# Altium schematic sheet Sheet1.SchDoc(Sheet1)
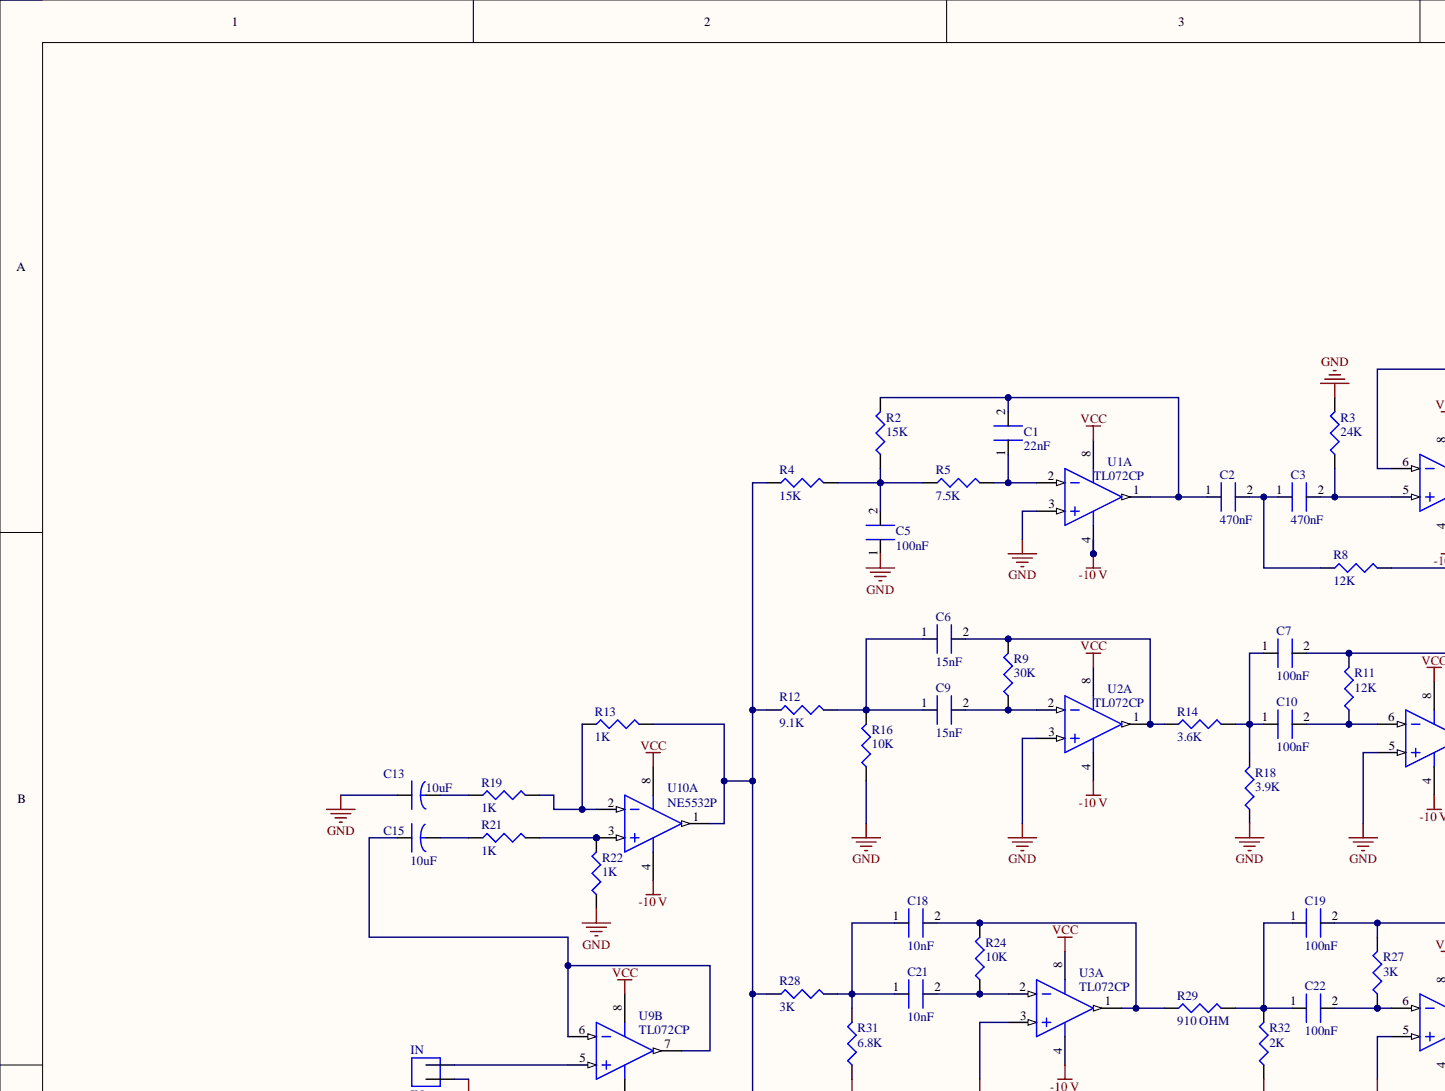
[diagram p0: Sheet1.SchDoc, top left]
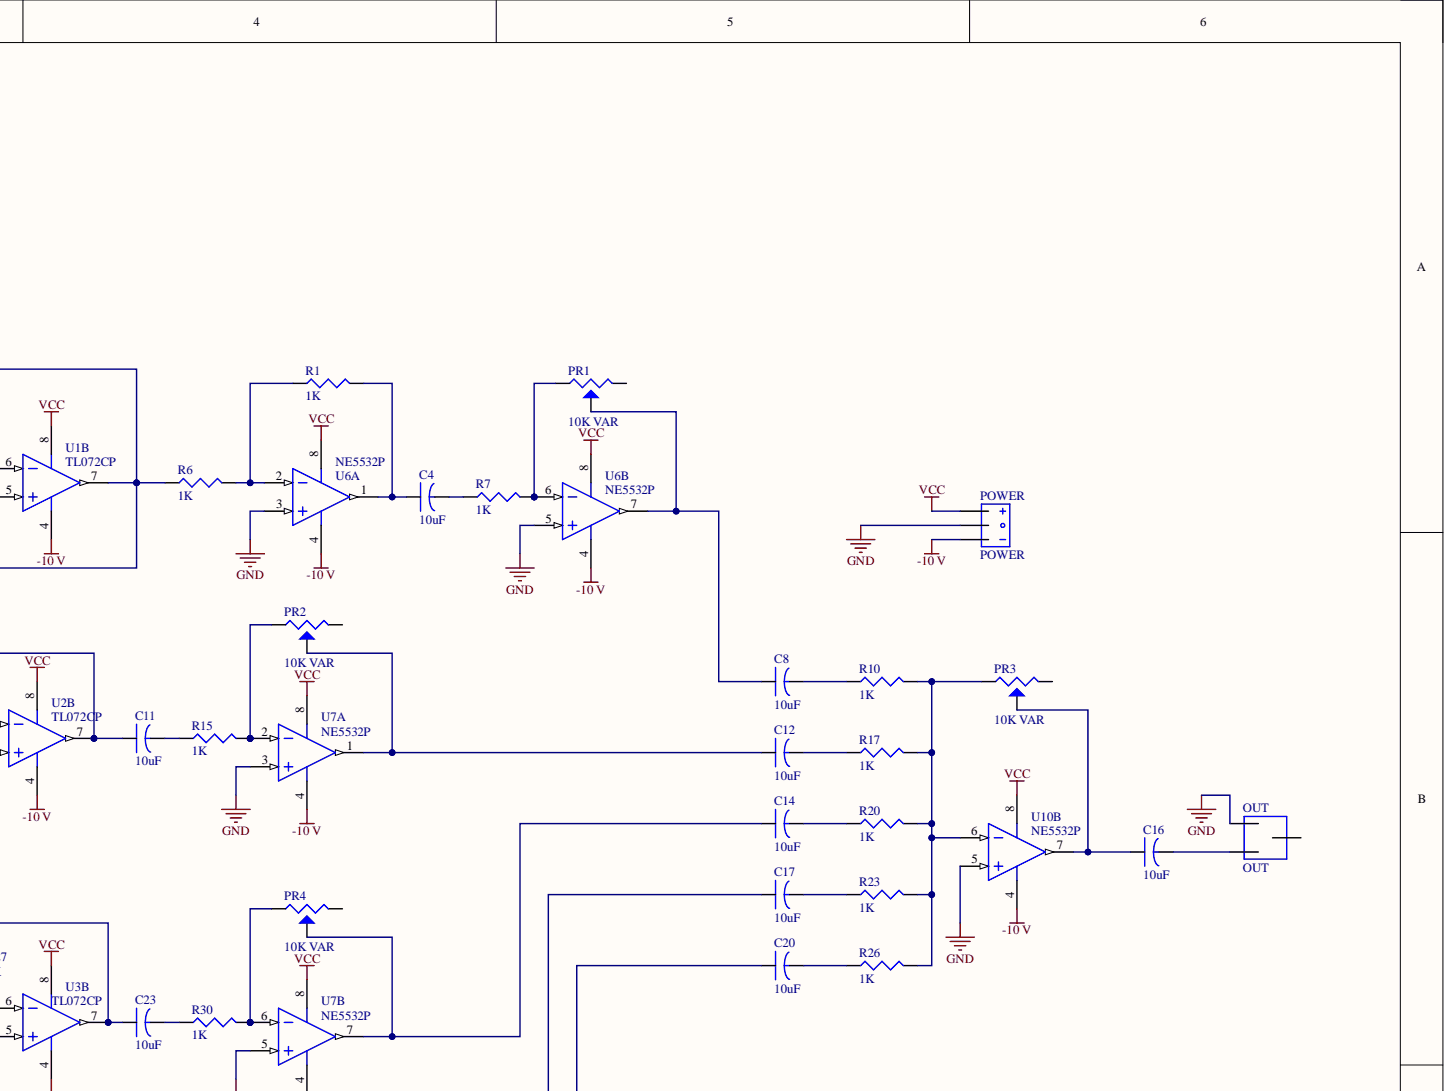
[diagram p1: Sheet1.SchDoc, top right]
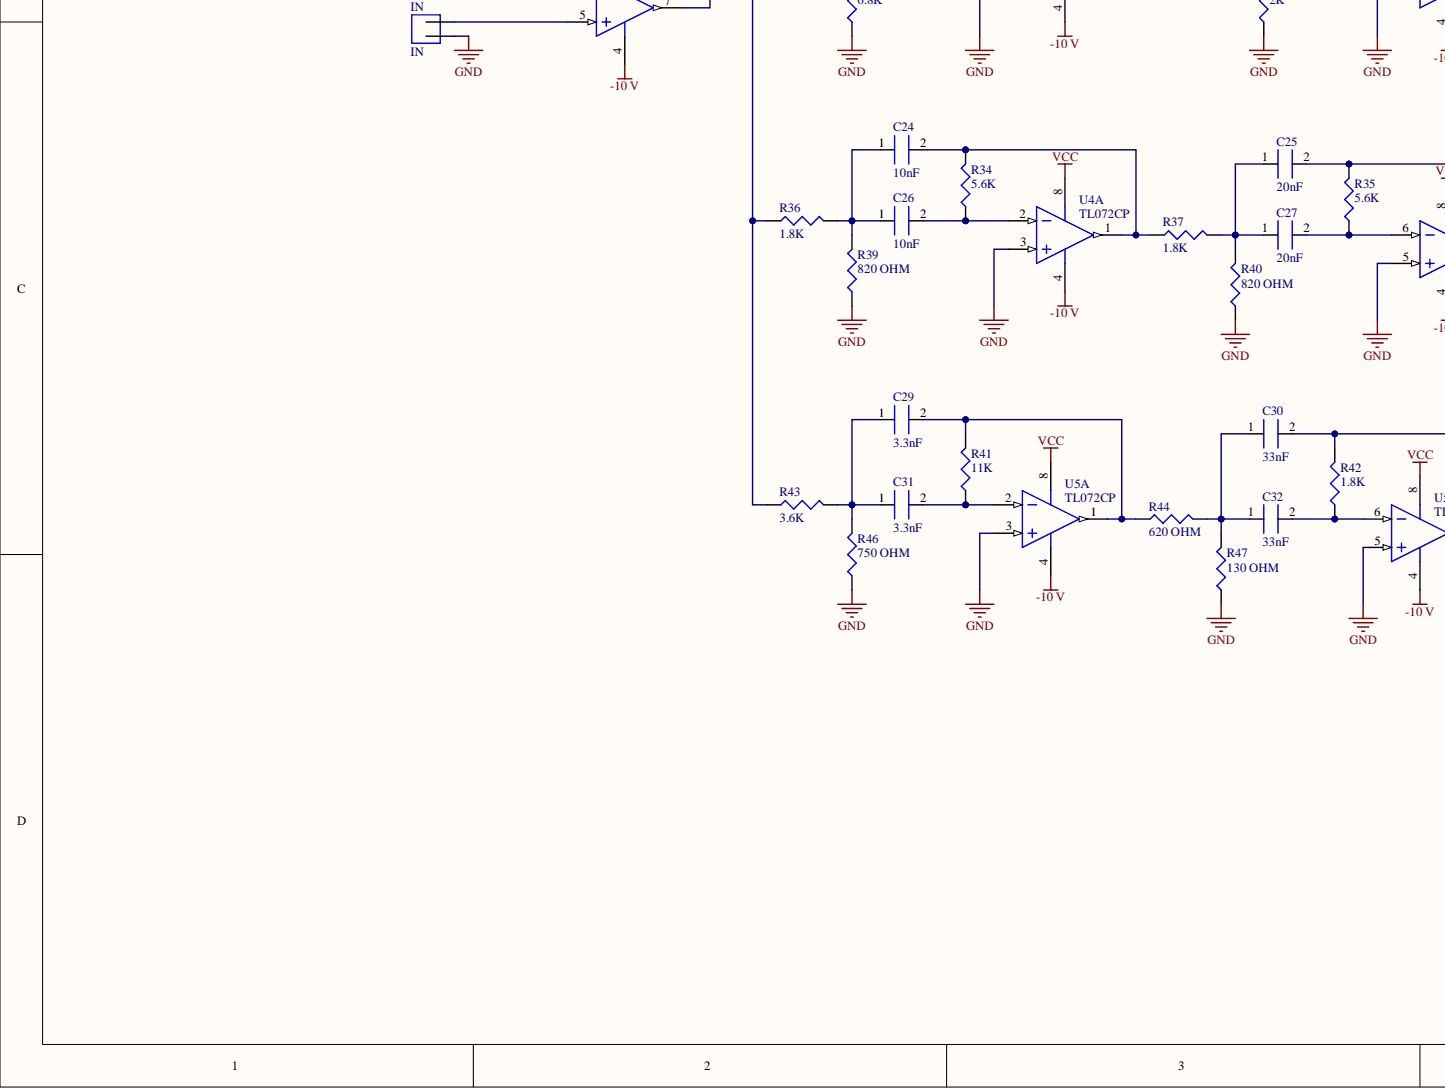
[diagram p2: Sheet1.SchDoc, bottom left]
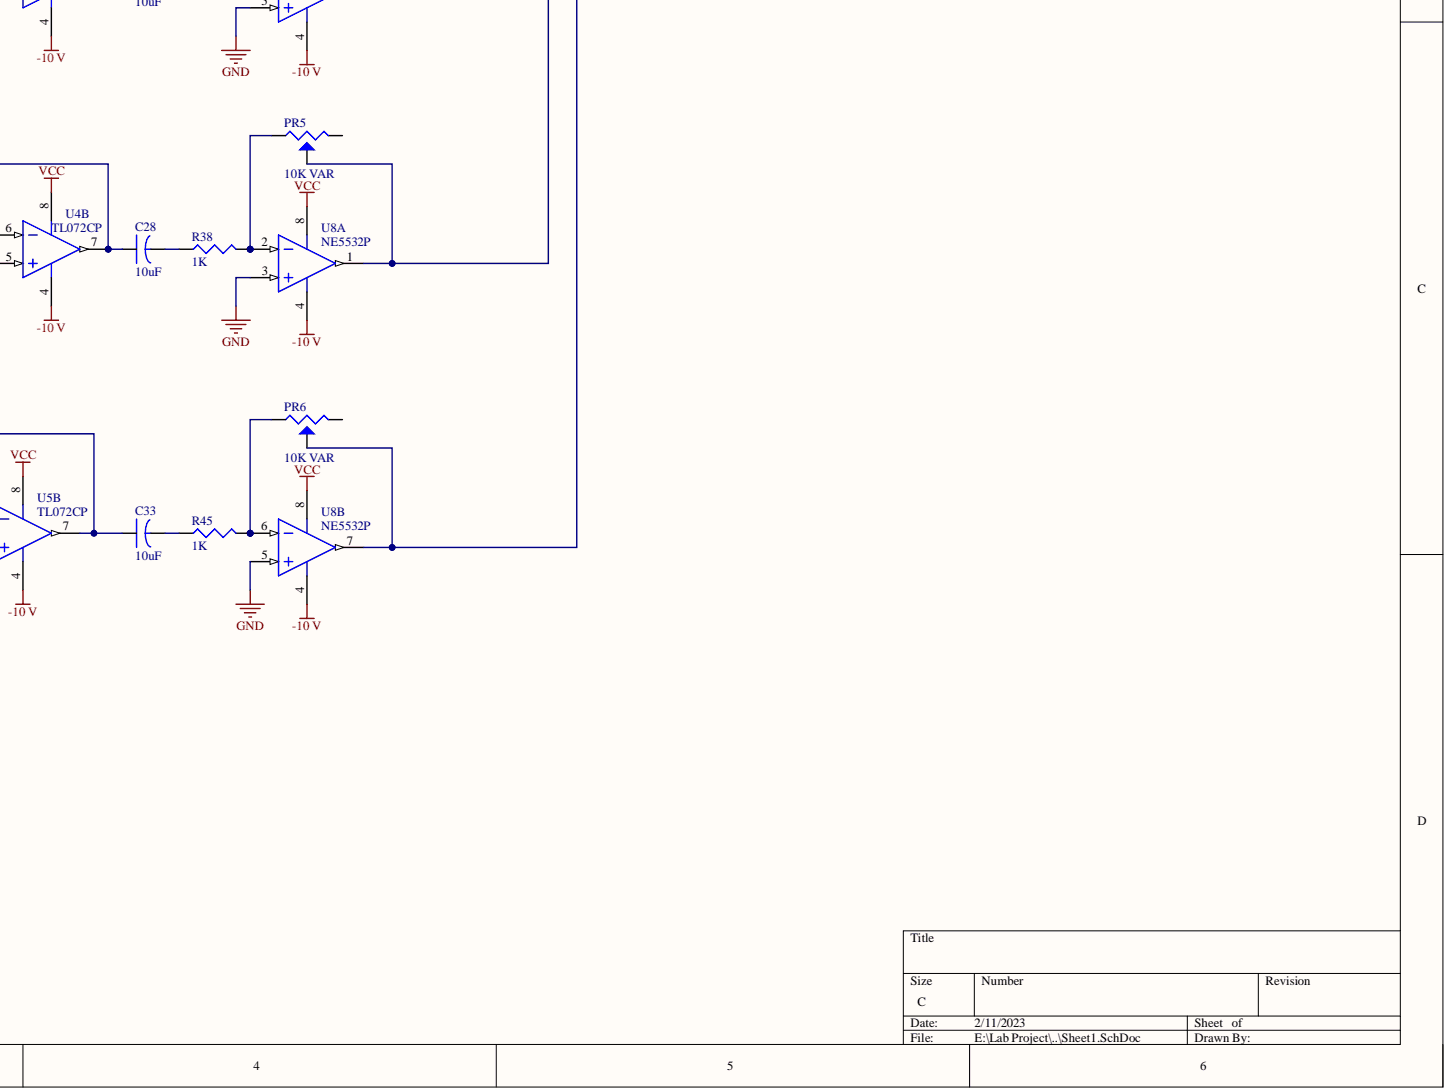
[diagram p3: Sheet1.SchDoc, bottom right]

## Parts
C1  22nF  [10nF]
C2  470nF  [100nf]
C3  470nF  [100nf]
C4  10uF  [10uF]
C5  100nF  [100nf]
C6  15nF  [10nF]
C7  100nF  [100nf]
C8  10uF  [10uF]
C9  15nF  [10nF]
C10  100nF  [100nf]
C11  10uF  [10uF]
C12  10uF  [10uF]
C13  10uF  [10uF]
C14  10uF  [10uF]
C15  10uF  [10uF]
C16  10uF  [10uF]
C17  10uF  [10uF]
C18  10nF  [10nF]
C19  100nF  [100nf]
C20  10uF  [10uF]
C21  10nF  [10nF]
C22  100nF  [100nf]
C23  10uF  [10uF]
C24  10nF  [10nF]
C25  20nF  [10nF]
C26  10nF  [10nF]
C27  20nF  [10nF]
C28  10uF  [10uF]
C29  3.3nF  [10nF]
C30  33nF  [10nF]
C31  3.3nF  [10nF]
C32  33nF  [10nF]
C33  10uF  [10uF]
IN  IN  [AUDIO_IN]
OUT  OUT  [AUDIO_OUT]
POWER  POWER  [POWER]
PR1  10K VAR  [POT]
PR2  10K VAR  [POT]
PR3  10K VAR  [POT]
PR4  10K VAR  [POT]
PR5  10K VAR  [POT]
PR6  10K VAR  [POT]
R1  1K  [RESI]
R2  15K  [RESI]
R3  24K  [RESI]
R4  15K  [RESI]
R5  7.5K  [RESI]
R6  1K  [RESI]
R7  1K  [RESI]
R8  12K  [RESI]
R9  30K  [RESI]
R10  1K  [RESI]
R11  12K  [RESI]
R12  9.1K  [RESI]
R13  1K  [RESI]
R14  3.6K  [RESI]
R15  1K  [RESI]
R16  10K  [RESI]
R17  1K  [RESI]
R18  3.9K  [RESI]
R19  1K  [RESI]
R20  1K  [RESI]
R21  1K  [RESI]
R22  1K  [RESI]
R23  1K  [RESI]
R24  10K  [RESI]
R26  1K  [RESI]
R27  3K  [RESI]
R28  3K  [RESI]
R29  910 OHM  [RESI]
R30  1K  [RESI]
R31  6.8K  [RESI]
R32  2K  [RESI]
R34  5.6K  [RESI]
R35  5.6K  [RESI]
R36  1.8K  [RESI]
R37  1.8K  [RESI]
R38  1K  [RESI]
R39  820 OHM  [RESI]
R40  820 OHM  [RESI]
R41  11K  [RESI]
R42  1.8K  [RESI]
R43  3.6K  [RESI]
R44  620 OHM  [RESI]
R45  1K  [RESI]
R46  750 OHM  [RESI]
R47  130 OHM  [RESI]
U1  TL072CP  [TL0072CP]  (parts A,B)
U2  TL072CP  [TL0072CP]  (parts A,B)
U3  TL072CP  [TL0072CP]  (parts A,B)
U4  TL072CP  [TL0072CP]  (parts A,B)
U5  TL072CP  [TL0072CP]  (parts A,B)
U6  NE5532P  [NE5532P]  (parts A,B)
U7  NE5532P  [NE5532P]  (parts A,B)
U8  NE5532P  [NE5532P]  (parts A,B)
U9  TL072CP  [TL0072CP]  (part B)
U10  NE5532P  [NE5532P]  (parts A,B)

## Nets
-10 V: POWER.3 U1.4 U2.4 U3.4 U4.4 U5.4 U6.4 U7.4 U8.4 U9.4 U10.4
GND: C5.1 C13.1 IN.1 OUT.2 POWER.2 R3.2 R16.1 R18.1 R22.1 R31.1 R32.1 R39.1 R40.1 R46.1 R47.1 U1.3 U2.3 U2.5 U3.3 U3.5 U4.3 U4.5 U5.3 U5.5 U6.3 U6.5 U7.3 U7.5 U8.3 U8.5 U10.5
VCC: POWER.1 U1.8 U2.8 U3.8 U4.8 U5.8 U6.8 U7.8 U8.8 U9.8 U10.8
NetC1_1: C1.1 R5.2 U1.2
NetC1_2: C1.2 C2.1 R2.2 U1.1
NetC2_2: C2.2 C3.1 R8.1
NetC3_2: C3.2 R3.1 U1.5
NetC4_1: C4.1 R1.2 U6.1
NetC4_2: C4.2 R7.1
NetC5_2: C5.2 R2.1 R4.2 R5.1
NetC6_1: C6.1 C9.1 R12.2 R16.2
NetC6_2: C6.2 R9.2 R14.1 U2.1
NetC7_1: C7.1 C10.1 R14.2 R18.2
NetC7_2: C7.2 C11.1 R11.2 U2.7
NetC8_1: C8.1 PR1.2 U6.7
NetC8_2: C8.2 R10.1
NetC9_2: C9.2 R9.1 U2.2
NetC10_2: C10.2 R11.1 U2.6
NetC11_2: C11.2 R15.1
NetC12_1: C12.1 PR2.2 U7.1
NetC12_2: C12.2 R17.1
NetC13_2: C13.2 R19.1
NetC14_1: C14.1 PR4.2 U7.7
NetC14_2: C14.2 R20.1
NetC15_1: C15.1 U9.6 U9.7
NetC15_2: C15.2 R21.1
NetC16_1: C16.1 PR3.2 U10.7
NetC16_2: C16.2 OUT.3
NetC17_1: C17.1 PR5.2 U8.1
NetC17_2: C17.2 R23.1
NetC18_1: C18.1 C21.1 R28.2 R31.2
NetC18_2: C18.2 R24.2 R29.1 U3.1
NetC19_1: C19.1 C22.1 R29.2 R32.2
NetC19_2: C19.2 C23.1 R27.2 U3.7
NetC20_1: C20.1 PR6.2 U8.7
NetC20_2: C20.2 R26.1
NetC21_2: C21.2 R24.1 U3.2
NetC22_2: C22.2 R27.1 U3.6
NetC23_2: C23.2 R30.1
NetC24_1: C24.1 C26.1 R36.2 R39.2
NetC24_2: C24.2 R34.2 R37.1 U4.1
NetC25_1: C25.1 C27.1 R37.2 R40.2
NetC25_2: C25.2 C28.1 R35.2 U4.7
NetC26_2: C26.2 R34.1 U4.2
NetC27_2: C27.2 R35.1 U4.6
NetC28_2: C28.2 R38.1
NetC29_1: C29.1 C31.1 R43.2 R46.2
NetC29_2: C29.2 R41.2 R44.1 U5.1
NetC30_1: C30.1 C32.1 R44.2 R47.2
NetC30_2: C30.2 C33.1 R42.2 U5.7
NetC31_2: C31.2 R41.1 U5.2
NetC32_2: C32.2 R42.1 U5.6
NetC33_2: C33.2 R45.1
NetIN_2: IN.2 U9.5
NetPR1_1: PR1.1 R7.2 U6.6
NetPR2_1: PR2.1 R15.2 U7.2
NetPR3_1: PR3.1 R10.2 R17.2 R20.2 R23.2 R26.2 U10.6
NetPR4_1: PR4.1 R30.2 U7.6
NetPR5_1: PR5.1 R38.2 U8.2
NetPR6_1: PR6.1 R45.2 U8.6
NetR1_1: R1.1 R6.2 U6.2
NetR4_1: R4.1 R12.1 R13.2 R28.1 R36.1 R43.1 U10.1
NetR6_1: R6.1 R8.2 U1.6 U1.7
NetR13_1: R13.1 R19.2 U10.2
NetR21_2: R21.2 R22.2 U10.3

Not connected: OUT 1; PR1 3; PR2 3; PR3 3; PR4 3; PR5 3; PR6 3

## Library data of the parts
C1 = 22nF: Manufacturer: Nichicon; Manufacturer Part Number: UKW1H0R1MDD1TD; Capacitance: 100nF; Life (Hours): 2000Hour; Ripple Current: 1.1mA; Tolerance: 20%; Voltage Rating: 50V; Case/Package: Radial; Category: Aluminum Electrolytic Capacitors; Component Kind: Standard; ComponentLink1Description: https://datasheet.ciiva.com/29550/e-kw-29550927.pdf?src-supplier=Digi-Key; ComponentLink1URL: https://datasheet.ciiva.com/29550/e-kw-29550927.pdf?src-supplier=Digi-Key; Height - Seated (Max): 0.492inch; Lead Pitch: 0.079inch; Library Name: Schlib1.SchLib; Max Operating Temperature: 85°C; Min Operating Temperature: -40°C; Mount: Through Hole; Package Quantity: 1; Packaging: Cut Tape; Pricing 1: 1=0.39000, 10=0.26600, 50=0.17720, 100=0.15050, 500=0.10626, 1000=0.09298 (USD); RoHS: Yes; RoHS Compliant: Yes; Stock 1: 3707; Supplier 1: Digi-Key; Supplier Part Number 1: 493-10793-1-ND
C2 C3 = 470nF: Manufacturer: Nichicon; Manufacturer Part Number: UKW1H0R1MDD1TD; Life (Hours): 2000Hour; Ripple Current: 1.1mA; Tolerance: 20%; Voltage Rating: 50V; Capacitance: 100nF; Case/Package: Radial; Category: Aluminum Electrolytic Capacitors; Component Kind: Standard; ComponentLink1Description: https://datasheet.ciiva.com/29550/e-kw-29550927.pdf?src-supplier=Digi-Key; ComponentLink1URL: https://datasheet.ciiva.com/29550/e-kw-29550927.pdf?src-supplier=Digi-Key; Height - Seated (Max): 0.492inch; Lead Pitch: 0.079inch; Library Name: Schlib1.SchLib; Max Operating Temperature: 85°C; Min Operating Temperature: -40°C; Mount: Through Hole; Package Quantity: 1; Packaging: Cut Tape; Pricing 1: 1=0.39000, 10=0.26600, 50=0.17720, 100=0.15050, 500=0.10626, 1000=0.09298 (USD); RoHS: Yes; RoHS Compliant: Yes; Stock 1: 3707; Supplier 1: Digi-Key; Supplier Part Number 1: 493-10793-1-ND
C4 C8 C11-C17 C20 C23 C28 C33 = 10uF: YXF series 50V 10uF 5x11mm LS=2mm 105'C 10k HRS Aluminum Electrolytic Capacitor; Manufacturer: Rubycon; Manufacturer Part Number: 50YXF10MEFC5X11; Dielectric Material: Aluminium; Impedance: 1.5R; Life (Hours): 5000Hour; Ripple Current: 100mA; Tolerance: 20%; Capacitance: 10uF; Case/Package: Radial; Category: Aluminum Electrolytic Capacitors; Component Kind: Standard; ComponentLink1Description: https://datasheet.ciiva.com/7976/e-yxf-7976037.pdf?src-supplier=Element14; ComponentLink1URL: https://datasheet.ciiva.com/7976/e-yxf-7976037.pdf?src-supplier=Element14; ComponentLink2Description: https://datasheet.ciiva.com/6310/e-yxf-6310901.pdf?src-supplier=Digi-Key; ComponentLink2URL: https://datasheet.ciiva.com/6310/e-yxf-6310901.pdf?src-supplier=Digi-Key; Diameter: 5mm; Height: 11mm; Height - Seated (Max): 0.492inch; Lead Pitch: 2mm; Library Name: Schlib1.SchLib; Max Operating Temperature: 105°C; Min Operating Temperature: -40°C; Mount: Through Hole; Package Quantity: 3000; Packaging: Bulk; Pricing 1: 1=0.31000, 10=0.21000, 50=0.12580, 100=0.10620, 500=0.07266, 1000=0.06427, 2500=0.06148, 5000=0.05589 (USD); Radiation Hardening: No; RoHS: Yes; RoHS Compliant: Yes; Series: YXF; Stock 1: 126340; Supplier 1: Digi-Key; Supplier Part Number 1: 1189-1852-ND; Termination: Radial; Voltage Rating: 50V
C5 C7 C10 C19 C22 = 100nF: Manufacturer: Nichicon; Manufacturer Part Number: UKW1H0R1MDD1TD; Life (Hours): 2000Hour; Ripple Current: 1.1mA; Tolerance: 20%; Voltage Rating: 50V; Capacitance: 100nF; Case/Package: Radial; Category: Aluminum Electrolytic Capacitors; Component Kind: Standard; ComponentLink1Description: https://datasheet.ciiva.com/29550/e-kw-29550927.pdf?src-supplier=Digi-Key; ComponentLink1URL: https://datasheet.ciiva.com/29550/e-kw-29550927.pdf?src-supplier=Digi-Key; Height - Seated (Max): 0.492inch; Lead Pitch: 0.079inch; Library Name: Schlib1.SchLib; Max Operating Temperature: 85°C; Min Operating Temperature: -40°C; Mount: Through Hole; Package Quantity: 1; Packaging: Cut Tape; Pricing 1: 1=0.39000, 10=0.26600, 50=0.17720, 100=0.15050, 500=0.10626, 1000=0.09298 (USD); RoHS: Yes; RoHS Compliant: Yes; Stock 1: 3707; Supplier 1: Digi-Key; Supplier Part Number 1: 493-10793-1-ND
C6 C9 = 15nF: Manufacturer: Nichicon; Manufacturer Part Number: UKW1H0R1MDD1TD; Capacitance: 100nF; Life (Hours): 2000Hour; Ripple Current: 1.1mA; Tolerance: 20%; Voltage Rating: 50V; Case/Package: Radial; Category: Aluminum Electrolytic Capacitors; Component Kind: Standard; ComponentLink1Description: https://datasheet.ciiva.com/29550/e-kw-29550927.pdf?src-supplier=Digi-Key; ComponentLink1URL: https://datasheet.ciiva.com/29550/e-kw-29550927.pdf?src-supplier=Digi-Key; Height - Seated (Max): 0.492inch; Lead Pitch: 0.079inch; Library Name: Schlib1.SchLib; Max Operating Temperature: 85°C; Min Operating Temperature: -40°C; Mount: Through Hole; Package Quantity: 1; Packaging: Cut Tape; Pricing 1: 1=0.39000, 10=0.26600, 50=0.17720, 100=0.15050, 500=0.10626, 1000=0.09298 (USD); RoHS: Yes; RoHS Compliant: Yes; Stock 1: 3707; Supplier 1: Digi-Key; Supplier Part Number 1: 493-10793-1-ND
C18 C21 C24 C26 = 10nF: Manufacturer: Nichicon; Manufacturer Part Number: UKW1H0R1MDD1TD; Capacitance: 100nF; Life (Hours): 2000Hour; Ripple Current: 1.1mA; Tolerance: 20%; Voltage Rating: 50V; Case/Package: Radial; Category: Aluminum Electrolytic Capacitors; Component Kind: Standard; ComponentLink1Description: https://datasheet.ciiva.com/29550/e-kw-29550927.pdf?src-supplier=Digi-Key; ComponentLink1URL: https://datasheet.ciiva.com/29550/e-kw-29550927.pdf?src-supplier=Digi-Key; Height - Seated (Max): 0.492inch; Lead Pitch: 0.079inch; Library Name: Schlib1.SchLib; Max Operating Temperature: 85°C; Min Operating Temperature: -40°C; Mount: Through Hole; Package Quantity: 1; Packaging: Cut Tape; Pricing 1: 1=0.39000, 10=0.26600, 50=0.17720, 100=0.15050, 500=0.10626, 1000=0.09298 (USD); RoHS: Yes; RoHS Compliant: Yes; Stock 1: 3707; Supplier 1: Digi-Key; Supplier Part Number 1: 493-10793-1-ND
C25 C27 = 20nF: Manufacturer: Nichicon; Manufacturer Part Number: UKW1H0R1MDD1TD; Capacitance: 100nF; Life (Hours): 2000Hour; Ripple Current: 1.1mA; Tolerance: 20%; Voltage Rating: 50V; Case/Package: Radial; Category: Aluminum Electrolytic Capacitors; Component Kind: Standard; ComponentLink1Description: https://datasheet.ciiva.com/29550/e-kw-29550927.pdf?src-supplier=Digi-Key; ComponentLink1URL: https://datasheet.ciiva.com/29550/e-kw-29550927.pdf?src-supplier=Digi-Key; Height - Seated (Max): 0.492inch; Lead Pitch: 0.079inch; Library Name: Schlib1.SchLib; Max Operating Temperature: 85°C; Min Operating Temperature: -40°C; Mount: Through Hole; Package Quantity: 1; Packaging: Cut Tape; Pricing 1: 1=0.39000, 10=0.26600, 50=0.17720, 100=0.15050, 500=0.10626, 1000=0.09298 (USD); RoHS: Yes; RoHS Compliant: Yes; Stock 1: 3707; Supplier 1: Digi-Key; Supplier Part Number 1: 493-10793-1-ND
C29 C31 = 3.3nF: Manufacturer: Nichicon; Manufacturer Part Number: UKW1H0R1MDD1TD; Capacitance: 100nF; Life (Hours): 2000Hour; Ripple Current: 1.1mA; Tolerance: 20%; Voltage Rating: 50V; Case/Package: Radial; Category: Aluminum Electrolytic Capacitors; Component Kind: Standard; ComponentLink1Description: https://datasheet.ciiva.com/29550/e-kw-29550927.pdf?src-supplier=Digi-Key; ComponentLink1URL: https://datasheet.ciiva.com/29550/e-kw-29550927.pdf?src-supplier=Digi-Key; Height - Seated (Max): 0.492inch; Lead Pitch: 0.079inch; Library Name: Schlib1.SchLib; Max Operating Temperature: 85°C; Min Operating Temperature: -40°C; Mount: Through Hole; Package Quantity: 1; Packaging: Cut Tape; Pricing 1: 1=0.39000, 10=0.26600, 50=0.17720, 100=0.15050, 500=0.10626, 1000=0.09298 (USD); RoHS: Yes; RoHS Compliant: Yes; Stock 1: 3707; Supplier 1: Digi-Key; Supplier Part Number 1: 493-10793-1-ND
C30 C32 = 33nF: Manufacturer: Nichicon; Manufacturer Part Number: UKW1H0R1MDD1TD; Capacitance: 100nF; Life (Hours): 2000Hour; Ripple Current: 1.1mA; Tolerance: 20%; Voltage Rating: 50V; Case/Package: Radial; Category: Aluminum Electrolytic Capacitors; Component Kind: Standard; ComponentLink1Description: https://datasheet.ciiva.com/29550/e-kw-29550927.pdf?src-supplier=Digi-Key; ComponentLink1URL: https://datasheet.ciiva.com/29550/e-kw-29550927.pdf?src-supplier=Digi-Key; Height - Seated (Max): 0.492inch; Lead Pitch: 0.079inch; Library Name: Schlib1.SchLib; Max Operating Temperature: 85°C; Min Operating Temperature: -40°C; Mount: Through Hole; Package Quantity: 1; Packaging: Cut Tape; Pricing 1: 1=0.39000, 10=0.26600, 50=0.17720, 100=0.15050, 500=0.10626, 1000=0.09298 (USD); RoHS: Yes; RoHS Compliant: Yes; Stock 1: 3707; Supplier 1: Digi-Key; Supplier Part Number 1: 493-10793-1-ND
IN = A_IN: Component Kind: Standard; Library Name: Schlib1.SchLib
OUT = AUDIO: Component Kind: Standard; Library Name: Schlib1.SchLib
R1 R6 R7 R10 R13 R15 R17 R19-R23 R26 R30 R38 R45 = 1K: RES 1K OHM 5% 1/2W AXIAL; Manufacturer: Yageo; Manufacturer Part Number: CFR-25JB-52-10K; Max Power Dissipation: 250mW; Resistance: 10kR; Temperature Coefficient: 500ppm/°C; Case/Package: Axial; Category: Through-Hole Resistors; Component Kind: Standard; ComponentLink1Description: http://www.yageo.com/NewPortal/yageodocoutput?fileName=/pdf/throughhole/Yageo_LR_CFR_2013.pdf&src-supplier=Digi-Key; ComponentLink1URL: http://www.yageo.com/NewPortal/yageodocoutput?fileName=/pdf/throughhole/Yageo_LR_CFR_2013.pdf&src-supplier=Digi-Key; Lead Free: Lead Free; Length: 0.248inch; Library Name: Schlib1.SchLib; Max Operating Temperature: 155°C; Min Operating Temperature: -55°C; Number of Terminations: 2; Package Quantity: 200; Packaging: Bulk; Pricing 1: 1=0.10000, 10=0.06800, 25=0.04680, 50=0.03640, 100=0.02600, 250=0.02028, 500=0.01612, 1000=0.01144, 5000=0.00884 (USD); Resistor Type: Carbon Film; RoHS: Yes; RoHS Compliant: Yes; Series: Carbon Film; Stock 1: 199228; Supplier 1: Digi-Key; Supplier Part Number 1: CF14JT1K00CT-ND; Tolerance: 5%
R2 R4 = 15K: RES 15K OHM 5% 1/2W AXIAL; Manufacturer: Yageo; Manufacturer Part Number: CFR-25JB-52-10K; Max Power Dissipation: 250mW; Resistance: 10kR; Temperature Coefficient: 500ppm/°C; Case/Package: Axial; Category: Through-Hole Resistors; Component Kind: Standard; ComponentLink1Description: http://www.yageo.com/NewPortal/yageodocoutput?fileName=/pdf/throughhole/Yageo_LR_CFR_2013.pdf&src-supplier=Digi-Key; ComponentLink1URL: http://www.yageo.com/NewPortal/yageodocoutput?fileName=/pdf/throughhole/Yageo_LR_CFR_2013.pdf&src-supplier=Digi-Key; Lead Free: Lead Free; Length: 0.248inch; Library Name: Schlib1.SchLib; Max Operating Temperature: 155°C; Min Operating Temperature: -55°C; Number of Terminations: 2; Package Quantity: 200; Packaging: Bulk; Pricing 1: 1=0.10000, 10=0.06800, 25=0.04680, 50=0.03640, 100=0.02600, 250=0.02028, 500=0.01612, 1000=0.01144, 5000=0.00884 (USD); Resistor Type: Carbon Film; RoHS: Yes; RoHS Compliant: Yes; Series: Carbon Film; Stock 1: 199228; Supplier 1: Digi-Key; Supplier Part Number 1: 10KQBK-ND; Tolerance: 5%
R3 = 24K: RES 24K OHM 5% 1/2W AXIAL; Manufacturer: Yageo; Manufacturer Part Number: CFR-25JB-52-10K; Max Power Dissipation: 250mW; Resistance: 10kR; Temperature Coefficient: 500ppm/°C; Case/Package: Axial; Category: Through-Hole Resistors; Component Kind: Standard; ComponentLink1Description: http://www.yageo.com/NewPortal/yageodocoutput?fileName=/pdf/throughhole/Yageo_LR_CFR_2013.pdf&src-supplier=Digi-Key; ComponentLink1URL: http://www.yageo.com/NewPortal/yageodocoutput?fileName=/pdf/throughhole/Yageo_LR_CFR_2013.pdf&src-supplier=Digi-Key; Lead Free: Lead Free; Length: 0.248inch; Library Name: Schlib1.SchLib; Max Operating Temperature: 155°C; Min Operating Temperature: -55°C; Number of Terminations: 2; Package Quantity: 200; Packaging: Bulk; Pricing 1: 1=0.10000, 10=0.06800, 25=0.04680, 50=0.03640, 100=0.02600, 250=0.02028, 500=0.01612, 1000=0.01144, 5000=0.00884 (USD); Resistor Type: Carbon Film; RoHS: Yes; RoHS Compliant: Yes; Series: Carbon Film; Stock 1: 199228; Supplier 1: Digi-Key; Supplier Part Number 1: 10KQBK-ND; Tolerance: 5%
R5 = 7.5K: RES 7.5K OHM 5% 1/2W AXIAL; Manufacturer: Yageo; Manufacturer Part Number: CFR-25JB-52-10K; Max Power Dissipation: 250mW; Resistance: 10kR; Temperature Coefficient: 500ppm/°C; Case/Package: Axial; Category: Through-Hole Resistors; Component Kind: Standard; ComponentLink1Description: http://www.yageo.com/NewPortal/yageodocoutput?fileName=/pdf/throughhole/Yageo_LR_CFR_2013.pdf&src-supplier=Digi-Key; ComponentLink1URL: http://www.yageo.com/NewPortal/yageodocoutput?fileName=/pdf/throughhole/Yageo_LR_CFR_2013.pdf&src-supplier=Digi-Key; Lead Free: Lead Free; Length: 0.248inch; Library Name: Schlib1.SchLib; Max Operating Temperature: 155°C; Min Operating Temperature: -55°C; Number of Terminations: 2; Package Quantity: 200; Packaging: Bulk; Pricing 1: 1=0.10000, 10=0.06800, 25=0.04680, 50=0.03640, 100=0.02600, 250=0.02028, 500=0.01612, 1000=0.01144, 5000=0.00884 (USD); Resistor Type: Carbon Film; RoHS: Yes; RoHS Compliant: Yes; Series: Carbon Film; Stock 1: 199228; Supplier 1: Digi-Key; Supplier Part Number 1: 10KQBK-ND; Tolerance: 5%
R8 R11 = 12K: RES 12K OHM 5% 1/2W AXIAL; Manufacturer: Yageo; Manufacturer Part Number: CFR-25JB-52-10K; Max Power Dissipation: 250mW; Resistance: 10kR; Temperature Coefficient: 500ppm/°C; Case/Package: Axial; Category: Through-Hole Resistors; Component Kind: Standard; ComponentLink1Description: http://www.yageo.com/NewPortal/yageodocoutput?fileName=/pdf/throughhole/Yageo_LR_CFR_2013.pdf&src-supplier=Digi-Key; ComponentLink1URL: http://www.yageo.com/NewPortal/yageodocoutput?fileName=/pdf/throughhole/Yageo_LR_CFR_2013.pdf&src-supplier=Digi-Key; Lead Free: Lead Free; Length: 0.248inch; Library Name: Schlib1.SchLib; Max Operating Temperature: 155°C; Min Operating Temperature: -55°C; Number of Terminations: 2; Package Quantity: 200; Packaging: Bulk; Pricing 1: 1=0.10000, 10=0.06800, 25=0.04680, 50=0.03640, 100=0.02600, 250=0.02028, 500=0.01612, 1000=0.01144, 5000=0.00884 (USD); Resistor Type: Carbon Film; RoHS: Yes; RoHS Compliant: Yes; Series: Carbon Film; Stock 1: 199228; Supplier 1: Digi-Key; Supplier Part Number 1: 10KQBK-ND; Tolerance: 5%
R9 = 30K: RES 30K OHM 5% 1/2W AXIAL; Manufacturer: Yageo; Manufacturer Part Number: CFR-25JB-52-10K; Max Power Dissipation: 250mW; Resistance: 10kR; Temperature Coefficient: 500ppm/°C; Case/Package: Axial; Category: Through-Hole Resistors; Component Kind: Standard; ComponentLink1Description: http://www.yageo.com/NewPortal/yageodocoutput?fileName=/pdf/throughhole/Yageo_LR_CFR_2013.pdf&src-supplier=Digi-Key; ComponentLink1URL: http://www.yageo.com/NewPortal/yageodocoutput?fileName=/pdf/throughhole/Yageo_LR_CFR_2013.pdf&src-supplier=Digi-Key; Lead Free: Lead Free; Length: 0.248inch; Library Name: Schlib1.SchLib; Max Operating Temperature: 155°C; Min Operating Temperature: -55°C; Number of Terminations: 2; Package Quantity: 200; Packaging: Bulk; Pricing 1: 1=0.10000, 10=0.06800, 25=0.04680, 50=0.03640, 100=0.02600, 250=0.02028, 500=0.01612, 1000=0.01144, 5000=0.00884 (USD); Resistor Type: Carbon Film; RoHS: Yes; RoHS Compliant: Yes; Series: Carbon Film; Stock 1: 199228; Supplier 1: Digi-Key; Supplier Part Number 1: 10KQBK-ND; Tolerance: 5%
R12 = 9.1K: RES 9.1K OHM 5% 1/2W AXIAL; Manufacturer: Yageo; Manufacturer Part Number: CFR-25JB-52-10K; Max Power Dissipation: 250mW; Resistance: 10kR; Temperature Coefficient: 500ppm/°C; Case/Package: Axial; Category: Through-Hole Resistors; Component Kind: Standard; ComponentLink1Description: http://www.yageo.com/NewPortal/yageodocoutput?fileName=/pdf/throughhole/Yageo_LR_CFR_2013.pdf&src-supplier=Digi-Key; ComponentLink1URL: http://www.yageo.com/NewPortal/yageodocoutput?fileName=/pdf/throughhole/Yageo_LR_CFR_2013.pdf&src-supplier=Digi-Key; Lead Free: Lead Free; Length: 0.248inch; Library Name: Schlib1.SchLib; Max Operating Temperature: 155°C; Min Operating Temperature: -55°C; Number of Terminations: 2; Package Quantity: 200; Packaging: Bulk; Pricing 1: 1=0.10000, 10=0.06800, 25=0.04680, 50=0.03640, 100=0.02600, 250=0.02028, 500=0.01612, 1000=0.01144, 5000=0.00884 (USD); Resistor Type: Carbon Film; RoHS: Yes; RoHS Compliant: Yes; Series: Carbon Film; Stock 1: 199228; Supplier 1: Digi-Key; Supplier Part Number 1: 10KQBK-ND; Tolerance: 5%
R14 R43 = 3.6K: RES 3.6K OHM 5% 1/2W AXIAL; Manufacturer: Yageo; Manufacturer Part Number: CFR-25JB-52-10K; Max Power Dissipation: 250mW; Resistance: 10kR; Temperature Coefficient: 500ppm/°C; Case/Package: Axial; Category: Through-Hole Resistors; Component Kind: Standard; ComponentLink1Description: http://www.yageo.com/NewPortal/yageodocoutput?fileName=/pdf/throughhole/Yageo_LR_CFR_2013.pdf&src-supplier=Digi-Key; ComponentLink1URL: http://www.yageo.com/NewPortal/yageodocoutput?fileName=/pdf/throughhole/Yageo_LR_CFR_2013.pdf&src-supplier=Digi-Key; Lead Free: Lead Free; Length: 0.248inch; Library Name: Schlib1.SchLib; Max Operating Temperature: 155°C; Min Operating Temperature: -55°C; Number of Terminations: 2; Package Quantity: 200; Packaging: Bulk; Pricing 1: 1=0.10000, 10=0.06800, 25=0.04680, 50=0.03640, 100=0.02600, 250=0.02028, 500=0.01612, 1000=0.01144, 5000=0.00884 (USD); Resistor Type: Carbon Film; RoHS: Yes; RoHS Compliant: Yes; Series: Carbon Film; Stock 1: 199228; Supplier 1: Digi-Key; Supplier Part Number 1: 10KQBK-ND; Tolerance: 5%
R16 R24 = 10K: RES 10K OHM 5% 1/2W AXIAL; Manufacturer: Yageo; Manufacturer Part Number: CFR-25JB-52-10K; Max Power Dissipation: 250mW; Resistance: 10kR; Temperature Coefficient: 500ppm/°C; Case/Package: Axial; Category: Through-Hole Resistors; Component Kind: Standard; ComponentLink1Description: http://www.yageo.com/NewPortal/yageodocoutput?fileName=/pdf/throughhole/Yageo_LR_CFR_2013.pdf&src-supplier=Digi-Key; ComponentLink1URL: http://www.yageo.com/NewPortal/yageodocoutput?fileName=/pdf/throughhole/Yageo_LR_CFR_2013.pdf&src-supplier=Digi-Key; Lead Free: Lead Free; Length: 0.248inch; Library Name: Schlib1.SchLib; Max Operating Temperature: 155°C; Min Operating Temperature: -55°C; Number of Terminations: 2; Package Quantity: 200; Packaging: Bulk; Pricing 1: 1=0.10000, 10=0.06800, 25=0.04680, 50=0.03640, 100=0.02600, 250=0.02028, 500=0.01612, 1000=0.01144, 5000=0.00884 (USD); Resistor Type: Carbon Film; RoHS: Yes; RoHS Compliant: Yes; Series: Carbon Film; Stock 1: 199228; Supplier 1: Digi-Key; Supplier Part Number 1: 10KQBK-ND; Tolerance: 5%
R18 = 3.9K: RES 3.9K OHM 5% 1/2W AXIAL; Manufacturer: Yageo; Manufacturer Part Number: CFR-25JB-52-10K; Max Power Dissipation: 250mW; Resistance: 10kR; Temperature Coefficient: 500ppm/°C; Case/Package: Axial; Category: Through-Hole Resistors; Component Kind: Standard; ComponentLink1Description: http://www.yageo.com/NewPortal/yageodocoutput?fileName=/pdf/throughhole/Yageo_LR_CFR_2013.pdf&src-supplier=Digi-Key; ComponentLink1URL: http://www.yageo.com/NewPortal/yageodocoutput?fileName=/pdf/throughhole/Yageo_LR_CFR_2013.pdf&src-supplier=Digi-Key; Lead Free: Lead Free; Length: 0.248inch; Library Name: Schlib1.SchLib; Max Operating Temperature: 155°C; Min Operating Temperature: -55°C; Number of Terminations: 2; Package Quantity: 200; Packaging: Bulk; Pricing 1: 1=0.10000, 10=0.06800, 25=0.04680, 50=0.03640, 100=0.02600, 250=0.02028, 500=0.01612, 1000=0.01144, 5000=0.00884 (USD); Resistor Type: Carbon Film; RoHS: Yes; RoHS Compliant: Yes; Series: Carbon Film; Stock 1: 199228; Supplier 1: Digi-Key; Supplier Part Number 1: 10KQBK-ND; Tolerance: 5%
R27 R28 = 3K: RES 3K OHM 5% 1/2W AXIAL; Manufacturer: Yageo; Manufacturer Part Number: CFR-25JB-52-10K; Max Power Dissipation: 250mW; Resistance: 10kR; Temperature Coefficient: 500ppm/°C; Case/Package: Axial; Category: Through-Hole Resistors; Component Kind: Standard; ComponentLink1Description: http://www.yageo.com/NewPortal/yageodocoutput?fileName=/pdf/throughhole/Yageo_LR_CFR_2013.pdf&src-supplier=Digi-Key; ComponentLink1URL: http://www.yageo.com/NewPortal/yageodocoutput?fileName=/pdf/throughhole/Yageo_LR_CFR_2013.pdf&src-supplier=Digi-Key; Lead Free: Lead Free; Length: 0.248inch; Library Name: Schlib1.SchLib; Max Operating Temperature: 155°C; Min Operating Temperature: -55°C; Number of Terminations: 2; Package Quantity: 200; Packaging: Bulk; Pricing 1: 1=0.10000, 10=0.06800, 25=0.04680, 50=0.03640, 100=0.02600, 250=0.02028, 500=0.01612, 1000=0.01144, 5000=0.00884 (USD); Resistor Type: Carbon Film; RoHS: Yes; RoHS Compliant: Yes; Series: Carbon Film; Stock 1: 199228; Supplier 1: Digi-Key; Supplier Part Number 1: 10KQBK-ND; Tolerance: 5%
R29 = 910 OHM: RES 910 OHM 5% 1/2W AXIAL; Manufacturer: Yageo; Manufacturer Part Number: CFR-25JB-52-10K; Max Power Dissipation: 250mW; Resistance: 10kR; Temperature Coefficient: 500ppm/°C; Case/Package: Axial; Category: Through-Hole Resistors; Component Kind: Standard; ComponentLink1Description: http://www.yageo.com/NewPortal/yageodocoutput?fileName=/pdf/throughhole/Yageo_LR_CFR_2013.pdf&src-supplier=Digi-Key; ComponentLink1URL: http://www.yageo.com/NewPortal/yageodocoutput?fileName=/pdf/throughhole/Yageo_LR_CFR_2013.pdf&src-supplier=Digi-Key; Lead Free: Lead Free; Length: 0.248inch; Library Name: Schlib1.SchLib; Max Operating Temperature: 155°C; Min Operating Temperature: -55°C; Number of Terminations: 2; Package Quantity: 200; Packaging: Bulk; Pricing 1: 1=0.10000, 10=0.06800, 25=0.04680, 50=0.03640, 100=0.02600, 250=0.02028, 500=0.01612, 1000=0.01144, 5000=0.00884 (USD); Resistor Type: Carbon Film; RoHS: Yes; RoHS Compliant: Yes; Series: Carbon Film; Stock 1: 199228; Supplier 1: Digi-Key; Supplier Part Number 1: 10KQBK-ND; Tolerance: 5%
R31 = 6.8K: RES 6.8K OHM 5% 1/2W AXIAL; Manufacturer: Yageo; Manufacturer Part Number: CFR-25JB-52-10K; Max Power Dissipation: 250mW; Resistance: 10kR; Temperature Coefficient: 500ppm/°C; Case/Package: Axial; Category: Through-Hole Resistors; Component Kind: Standard; ComponentLink1Description: http://www.yageo.com/NewPortal/yageodocoutput?fileName=/pdf/throughhole/Yageo_LR_CFR_2013.pdf&src-supplier=Digi-Key; ComponentLink1URL: http://www.yageo.com/NewPortal/yageodocoutput?fileName=/pdf/throughhole/Yageo_LR_CFR_2013.pdf&src-supplier=Digi-Key; Lead Free: Lead Free; Length: 0.248inch; Library Name: Schlib1.SchLib; Max Operating Temperature: 155°C; Min Operating Temperature: -55°C; Number of Terminations: 2; Package Quantity: 200; Packaging: Bulk; Pricing 1: 1=0.10000, 10=0.06800, 25=0.04680, 50=0.03640, 100=0.02600, 250=0.02028, 500=0.01612, 1000=0.01144, 5000=0.00884 (USD); Resistor Type: Carbon Film; RoHS: Yes; RoHS Compliant: Yes; Series: Carbon Film; Stock 1: 199228; Supplier 1: Digi-Key; Supplier Part Number 1: 10KQBK-ND; Tolerance: 5%
R32 = 2K: RES 2K OHM 5% 1/2W AXIAL; Manufacturer: Yageo; Manufacturer Part Number: CFR-25JB-52-10K; Max Power Dissipation: 250mW; Resistance: 10kR; Temperature Coefficient: 500ppm/°C; Case/Package: Axial; Category: Through-Hole Resistors; Component Kind: Standard; ComponentLink1Description: http://www.yageo.com/NewPortal/yageodocoutput?fileName=/pdf/throughhole/Yageo_LR_CFR_2013.pdf&src-supplier=Digi-Key; ComponentLink1URL: http://www.yageo.com/NewPortal/yageodocoutput?fileName=/pdf/throughhole/Yageo_LR_CFR_2013.pdf&src-supplier=Digi-Key; Lead Free: Lead Free; Length: 0.248inch; Library Name: Schlib1.SchLib; Max Operating Temperature: 155°C; Min Operating Temperature: -55°C; Number of Terminations: 2; Package Quantity: 200; Packaging: Bulk; Pricing 1: 1=0.10000, 10=0.06800, 25=0.04680, 50=0.03640, 100=0.02600, 250=0.02028, 500=0.01612, 1000=0.01144, 5000=0.00884 (USD); Resistor Type: Carbon Film; RoHS: Yes; RoHS Compliant: Yes; Series: Carbon Film; Stock 1: 199228; Supplier 1: Digi-Key; Supplier Part Number 1: 10KQBK-ND; Tolerance: 5%
R34 R35 = 5.6K: RES 5.6K OHM 5% 1/2W AXIAL; Manufacturer: Yageo; Manufacturer Part Number: CFR-25JB-52-10K; Max Power Dissipation: 250mW; Resistance: 10kR; Temperature Coefficient: 500ppm/°C; Case/Package: Axial; Category: Through-Hole Resistors; Component Kind: Standard; ComponentLink1Description: http://www.yageo.com/NewPortal/yageodocoutput?fileName=/pdf/throughhole/Yageo_LR_CFR_2013.pdf&src-supplier=Digi-Key; ComponentLink1URL: http://www.yageo.com/NewPortal/yageodocoutput?fileName=/pdf/throughhole/Yageo_LR_CFR_2013.pdf&src-supplier=Digi-Key; Lead Free: Lead Free; Length: 0.248inch; Library Name: Schlib1.SchLib; Max Operating Temperature: 155°C; Min Operating Temperature: -55°C; Number of Terminations: 2; Package Quantity: 200; Packaging: Bulk; Pricing 1: 1=0.10000, 10=0.06800, 25=0.04680, 50=0.03640, 100=0.02600, 250=0.02028, 500=0.01612, 1000=0.01144, 5000=0.00884 (USD); Resistor Type: Carbon Film; RoHS: Yes; RoHS Compliant: Yes; Series: Carbon Film; Stock 1: 199228; Supplier 1: Digi-Key; Supplier Part Number 1: 10KQBK-ND; Tolerance: 5%
R36 R37 R42 = 1.8K: RES 1.8K OHM 5% 1/2W AXIAL; Manufacturer: Yageo; Manufacturer Part Number: CFR-25JB-52-10K; Max Power Dissipation: 250mW; Resistance: 10kR; Temperature Coefficient: 500ppm/°C; Case/Package: Axial; Category: Through-Hole Resistors; Component Kind: Standard; ComponentLink1Description: http://www.yageo.com/NewPortal/yageodocoutput?fileName=/pdf/throughhole/Yageo_LR_CFR_2013.pdf&src-supplier=Digi-Key; ComponentLink1URL: http://www.yageo.com/NewPortal/yageodocoutput?fileName=/pdf/throughhole/Yageo_LR_CFR_2013.pdf&src-supplier=Digi-Key; Lead Free: Lead Free; Length: 0.248inch; Library Name: Schlib1.SchLib; Max Operating Temperature: 155°C; Min Operating Temperature: -55°C; Number of Terminations: 2; Package Quantity: 200; Packaging: Bulk; Pricing 1: 1=0.10000, 10=0.06800, 25=0.04680, 50=0.03640, 100=0.02600, 250=0.02028, 500=0.01612, 1000=0.01144, 5000=0.00884 (USD); Resistor Type: Carbon Film; RoHS: Yes; RoHS Compliant: Yes; Series: Carbon Film; Stock 1: 199228; Supplier 1: Digi-Key; Supplier Part Number 1: 10KQBK-ND; Tolerance: 5%
R39 R40 = 820 OHM: RES 820 OHM 5% 1/2W AXIAL; Manufacturer: Yageo; Manufacturer Part Number: CFR-25JB-52-10K; Max Power Dissipation: 250mW; Resistance: 10kR; Temperature Coefficient: 500ppm/°C; Case/Package: Axial; Category: Through-Hole Resistors; Component Kind: Standard; ComponentLink1Description: http://www.yageo.com/NewPortal/yageodocoutput?fileName=/pdf/throughhole/Yageo_LR_CFR_2013.pdf&src-supplier=Digi-Key; ComponentLink1URL: http://www.yageo.com/NewPortal/yageodocoutput?fileName=/pdf/throughhole/Yageo_LR_CFR_2013.pdf&src-supplier=Digi-Key; Lead Free: Lead Free; Length: 0.248inch; Library Name: Schlib1.SchLib; Max Operating Temperature: 155°C; Min Operating Temperature: -55°C; Number of Terminations: 2; Package Quantity: 200; Packaging: Bulk; Pricing 1: 1=0.10000, 10=0.06800, 25=0.04680, 50=0.03640, 100=0.02600, 250=0.02028, 500=0.01612, 1000=0.01144, 5000=0.00884 (USD); Resistor Type: Carbon Film; RoHS: Yes; RoHS Compliant: Yes; Series: Carbon Film; Stock 1: 199228; Supplier 1: Digi-Key; Supplier Part Number 1: 10KQBK-ND; Tolerance: 5%
R41 = 11K: RES 11K OHM 5% 1/2W AXIAL; Manufacturer: Yageo; Manufacturer Part Number: CFR-25JB-52-10K; Max Power Dissipation: 250mW; Resistance: 10kR; Temperature Coefficient: 500ppm/°C; Case/Package: Axial; Category: Through-Hole Resistors; Component Kind: Standard; ComponentLink1Description: http://www.yageo.com/NewPortal/yageodocoutput?fileName=/pdf/throughhole/Yageo_LR_CFR_2013.pdf&src-supplier=Digi-Key; ComponentLink1URL: http://www.yageo.com/NewPortal/yageodocoutput?fileName=/pdf/throughhole/Yageo_LR_CFR_2013.pdf&src-supplier=Digi-Key; Lead Free: Lead Free; Length: 0.248inch; Library Name: Schlib1.SchLib; Max Operating Temperature: 155°C; Min Operating Temperature: -55°C; Number of Terminations: 2; Package Quantity: 200; Packaging: Bulk; Pricing 1: 1=0.10000, 10=0.06800, 25=0.04680, 50=0.03640, 100=0.02600, 250=0.02028, 500=0.01612, 1000=0.01144, 5000=0.00884 (USD); Resistor Type: Carbon Film; RoHS: Yes; RoHS Compliant: Yes; Series: Carbon Film; Stock 1: 199228; Supplier 1: Digi-Key; Supplier Part Number 1: 10KQBK-ND; Tolerance: 5%
R44 = 620 OHM: RES 620 OHM 5% 1/2W AXIAL; Manufacturer: Yageo; Manufacturer Part Number: CFR-25JB-52-10K; Max Power Dissipation: 250mW; Resistance: 10kR; Temperature Coefficient: 500ppm/°C; Case/Package: Axial; Category: Through-Hole Resistors; Component Kind: Standard; ComponentLink1Description: http://www.yageo.com/NewPortal/yageodocoutput?fileName=/pdf/throughhole/Yageo_LR_CFR_2013.pdf&src-supplier=Digi-Key; ComponentLink1URL: http://www.yageo.com/NewPortal/yageodocoutput?fileName=/pdf/throughhole/Yageo_LR_CFR_2013.pdf&src-supplier=Digi-Key; Lead Free: Lead Free; Length: 0.248inch; Library Name: Schlib1.SchLib; Max Operating Temperature: 155°C; Min Operating Temperature: -55°C; Number of Terminations: 2; Package Quantity: 200; Packaging: Bulk; Pricing 1: 1=0.10000, 10=0.06800, 25=0.04680, 50=0.03640, 100=0.02600, 250=0.02028, 500=0.01612, 1000=0.01144, 5000=0.00884 (USD); Resistor Type: Carbon Film; RoHS: Yes; RoHS Compliant: Yes; Series: Carbon Film; Stock 1: 199228; Supplier 1: Digi-Key; Supplier Part Number 1: 10KQBK-ND; Tolerance: 5%
R46 = 750 OHM: RES 750 OHM 5% 1/2W AXIAL; Manufacturer: Yageo; Manufacturer Part Number: CFR-25JB-52-10K; Max Power Dissipation: 250mW; Resistance: 10kR; Temperature Coefficient: 500ppm/°C; Case/Package: Axial; Category: Through-Hole Resistors; Component Kind: Standard; ComponentLink1Description: http://www.yageo.com/NewPortal/yageodocoutput?fileName=/pdf/throughhole/Yageo_LR_CFR_2013.pdf&src-supplier=Digi-Key; ComponentLink1URL: http://www.yageo.com/NewPortal/yageodocoutput?fileName=/pdf/throughhole/Yageo_LR_CFR_2013.pdf&src-supplier=Digi-Key; Lead Free: Lead Free; Length: 0.248inch; Library Name: Schlib1.SchLib; Max Operating Temperature: 155°C; Min Operating Temperature: -55°C; Number of Terminations: 2; Package Quantity: 200; Packaging: Bulk; Pricing 1: 1=0.10000, 10=0.06800, 25=0.04680, 50=0.03640, 100=0.02600, 250=0.02028, 500=0.01612, 1000=0.01144, 5000=0.00884 (USD); Resistor Type: Carbon Film; RoHS: Yes; RoHS Compliant: Yes; Series: Carbon Film; Stock 1: 199228; Supplier 1: Digi-Key; Supplier Part Number 1: 10KQBK-ND; Tolerance: 5%
R47 = 130 OHM: RES 130 OHM 5% 1/2W AXIAL; Manufacturer: Yageo; Manufacturer Part Number: CFR-25JB-52-10K; Max Power Dissipation: 250mW; Resistance: 10kR; Temperature Coefficient: 500ppm/°C; Case/Package: Axial; Category: Through-Hole Resistors; Component Kind: Standard; ComponentLink1Description: http://www.yageo.com/NewPortal/yageodocoutput?fileName=/pdf/throughhole/Yageo_LR_CFR_2013.pdf&src-supplier=Digi-Key; ComponentLink1URL: http://www.yageo.com/NewPortal/yageodocoutput?fileName=/pdf/throughhole/Yageo_LR_CFR_2013.pdf&src-supplier=Digi-Key; Lead Free: Lead Free; Length: 0.248inch; Library Name: Schlib1.SchLib; Max Operating Temperature: 155°C; Min Operating Temperature: -55°C; Number of Terminations: 2; Package Quantity: 200; Packaging: Bulk; Pricing 1: 1=0.10000, 10=0.06800, 25=0.04680, 50=0.03640, 100=0.02600, 250=0.02028, 500=0.01612, 1000=0.01144, 5000=0.00884 (USD); Resistor Type: Carbon Film; RoHS: Yes; RoHS Compliant: Yes; Series: Carbon Film; Stock 1: 199228; Supplier 1: Digi-Key; Supplier Part Number 1: 10KQBK-ND; Tolerance: 5%
U1-U5 U9 = TL072CP: Dual Low-Noise JFET-Input General-Purpose Operational Amplifier, 7 to 36 V, 0 to 70 degC, 8-pin DIP (P8), Pb-Free (RoHS); Manufacturer: Texas Instruments; CMRR(Min)(dB): 70; GBW(Typ)(MHz): 3; IIB(Max)(pA): 200; Iq per channel(Max)(mA): 2.5; Number of Channels: 2; Offset Drift(Typ)(uV/C): 18; Rating: Catalog; Slew Rate(Typ)(V/us): 13; Sub Family: General Purpose Amplifier, High Supply Voltage Amplifier (>=30V); Total Supply Voltage(Max)(+5V is 5, +/-5V is 10): 36; Total Supply Voltage(Min)(+5V is 5, +/-5V is 10): 7; Vio (25C)(Max)(mV): 10; Code_JEDEC: MS-001; Component Kind: Standard; ComponentLink1Description: Manufacturer URL; ComponentLink1URL: http://www.ti.com/; ComponentLink2Description: Datasheet; ComponentLink2URL: http://www.ti.com/lit/ds/symlink/tl072.pdf; ComponentLink3Description: Package Specification; ComponentLink3URL: http://www.ti.com/litv/pdf/mpdi001b; DatasheetVersion: SLOS080J; Eco-Plan: Pb-Free (RoHS); Library Name: Schlib1.SchLib; Mounting Technology: Through Hole; PackageDescription: 8-Pin Plastic In-Line, Body 9.59 x 6.35 mm, Pitch 2.54 mm; PackageReference: P0008A; PackageVersion: 4040082/E, 04/2010; PartNumber: TL072CP; Vn at 1kHz(Typ)(nV/rtHz): 18
U6-U8 U10 = NE5532P: Dual Low-Noise High-Speed Audio Operational Amplifier, 10 to 30 V, 0 to 70 degC, 8-pin DIP (P8), Pb-Free (RoHS); Manufacturer: Texas Instruments; CMRR(Min)(dB): 70; GBW(Typ)(MHz): 10; IIB(Max)(pA): 800000; Iq per channel(Max)(mA): 4; Number of Channels: 2; Slew Rate(Typ)(V/us): 9; Sub Family: General Purpose Amplifier, High Supply Voltage Amplifier (>=30V), Low Noise Amp (<=10nV/rtHz); Total Supply Voltage(Max)(+5V is 5, +/-5V is 10): 30; Vn at 1kHz(Typ)(nV/rtHz): 5; Code_JEDEC: MS-001; Component Kind: Standard; ComponentLink1Description: Manufacturer URL; ComponentLink1URL: http://www.ti.com/; ComponentLink2Description: Datasheet; ComponentLink2URL: http://www.ti.com/lit/ds/symlink/ne5532.pdf; ComponentLink3Description: Package Specification; ComponentLink3URL: http://www.ti.com/litv/pdf/mpdi001b; DatasheetVersion: SLOS075I; Eco-Plan: Pb-Free (RoHS); Library Name: Schlib1.SchLib; Mounting Technology: Through Hole; PackageDescription: 8-Pin Plastic In-Line, Body 9.59 x 6.35 mm, Pitch 2.54 mm; PackageReference: P0008A; PackageVersion: 4040082/E, 04/2010; PartNumber: NE5532P; Total Supply Voltage(Min)(+5V is 5, +/-5V is 10): 10; Vio (25C)(Max)(mV): 4
POWER = POWER: Component Kind: Standard; Library Name: Schlib1.SchLib
PR1-PR6 = 10K VAR: Component Kind: Standard; Library Name: Schlib1.SchLib
